# Altium schematic sheet 03_PL_ETH.SchDoc
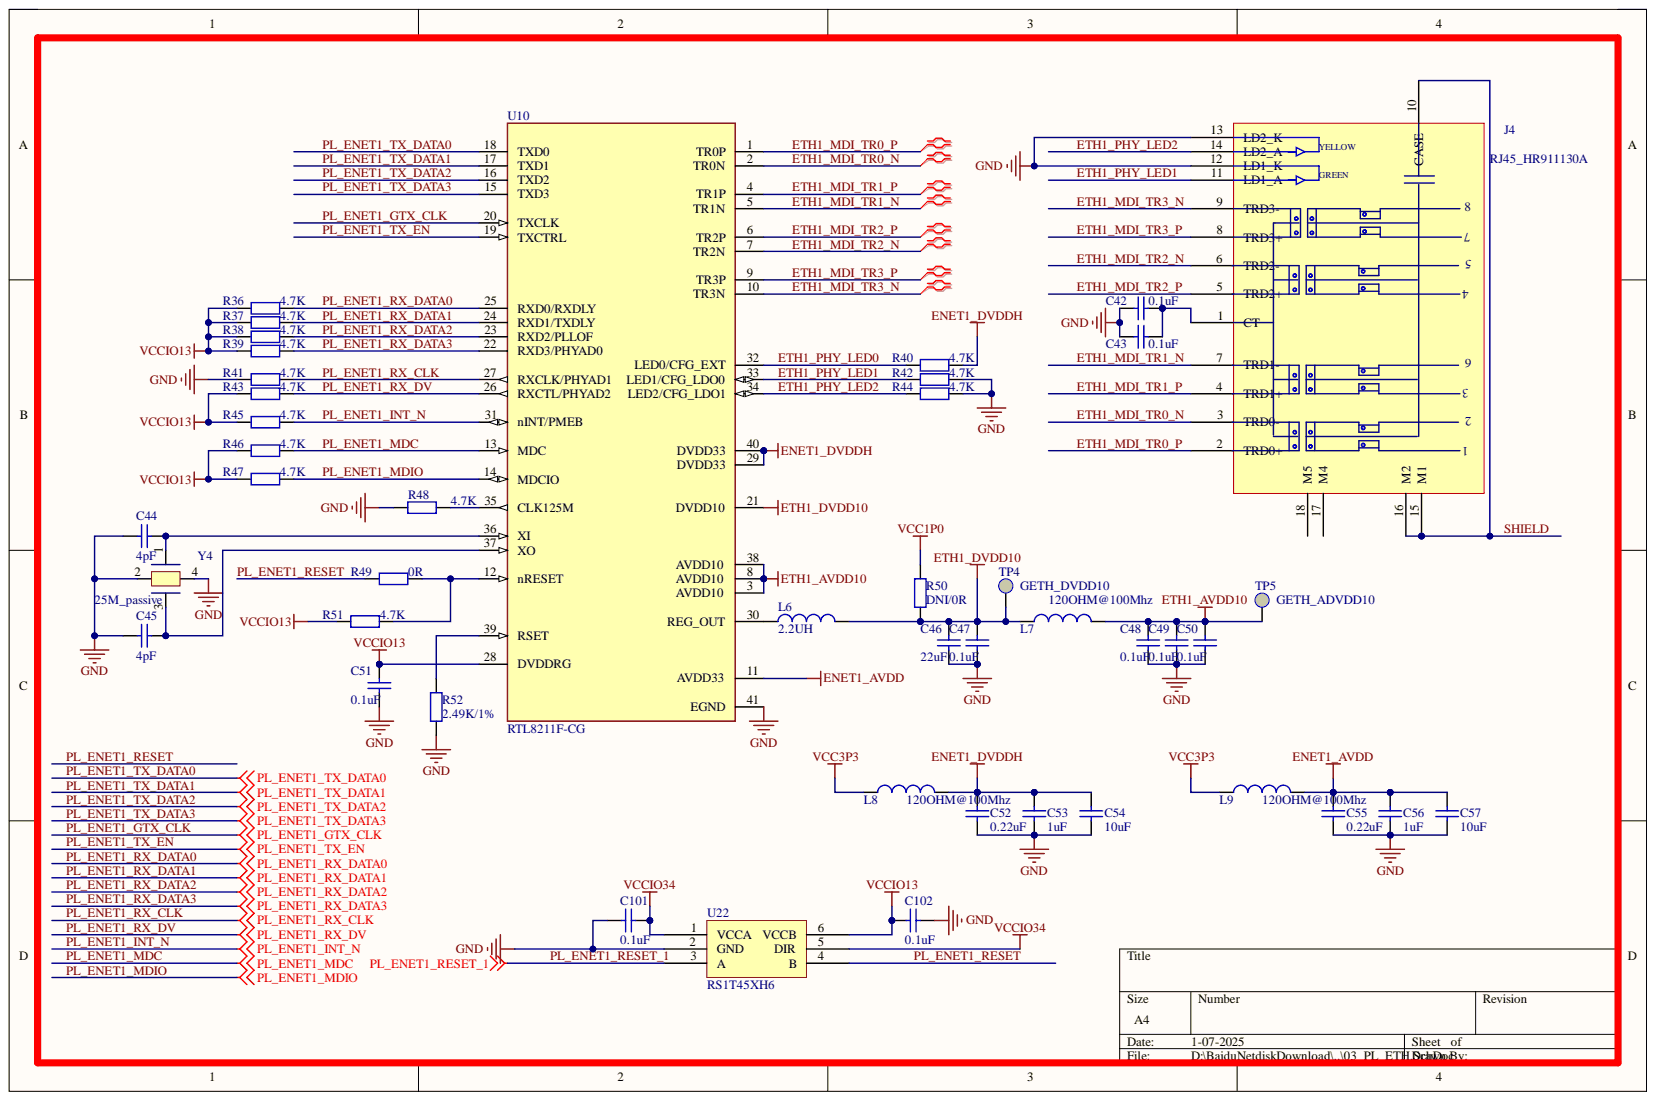
[diagram p0: 03_PL_ETH.SchDoc]

## Parts
C42  0.1uF  [C0603]
C43  0.1uF  [C0603]
C44  4pF  [C0603]
C45  4pF  [C0603]
C46  22uF  [C0805]
C47  0.1uF  [C0603]
C48  0.1uF  [C0603]
C49  0.1uF  [C0603]
C50  0.1uF  [C0603]
C51  0.1uF  [C0603]
C52  0.22uF  [C0603]
C53  1uF  [C0603]
C54  10uF  [C0805]
C55  0.22uF  [C0603]
C56  1uF  [C0603]
C57  10uF  [C0805]
C101  0.1uF  [C0603]
C102  0.1uF  [C0603]
J4  RJ45_HR911130A  [RJ45_HR911130C]
L6  2.2UH  [L0603]
L7  120OHM@100Mhz  [L0603]
L8  120OHM@100Mhz  [L0603]
L9  120OHM@100Mhz  [L0603]
R36  4.7K  [0603R]
R37  4.7K  [0603R]
R38  4.7K  [0603R]
R39  4.7K  [0603R]
R40  4.7K  [0603R]
R41  4.7K  [0603R]
R42  4.7K  [0603R]
R43  4.7K  [0603R]
R44  4.7K  [0603R]
R45  4.7K  [0603R]
R46  4.7K  [0603R]
R47  4.7K  [0603R]
R48  4.7K  [0603R]
R49  0R  [R0603]
R50  DNI/0R  [0603R]
R51  4.7K  [0603R]
R52  2.49K/1%  [0603R]
TP4  GETH_DVDD10  [tp32]
TP5  GETH_ADVDD10  [tp32]
U10  RTL8211F-CG  [qfn-40_l5_0-w5_0-p0_4-bl-ep]
U22  RS1T45XH6  [SOT23-6]
Y4  25M_passive  [X4-3225]

## Nets
ENET1_AVDD: C55.2 C56.2 C57.2 L9.2 U10.11(AVDD33)
ENET1_DVDDH: C52.2 C53.2 C54.2 L8.2 R40.2 U10.29(DVDD33) U10.40(DVDD33)
ETH1_AVDD10: C48.1 C49.1 C50.1 L7.2 TP5.1 U10.3(AVDD10) U10.8(AVDD10) U10.38(AVDD10)
ETH1_DVDD10: C46.1 C47.1 L6.2 L7.1 R50.2 TP4.1 U10.21(DVDD10)
GND: C42.1 C43.1 C44.1 C45.1 C46.2 C47.2 C48.2 C49.2 C50.2 C51.2 C52.1 C53.1 C54.1 C55.1 C56.1 C57.1 C101.1 C102.2 J4.12(LD1_K) J4.13(LD2_K) R41.1 R42.2 R44.2 R48.2 R52.1 U10.41(EGND) U22.2(GND) Y4.2 Y4.4
VCC1P0: R50.1
VCC3P3: L8.1 L9.1
VCCIO13: C51.1 C102.1 R36.1 R37.1 R38.1 R39.1 R43.1 R45.1 R46.1 R47.1 R51.1 U10.28(DVDDRG) U22.6(VCCB)
VCCIO34: C101.2 U22.1(VCCA) U22.5(DIR)
ETH1_MDI_TR0_N: J4.3(TRD0-) U10.2(TR0N)
ETH1_MDI_TR0_P: J4.2(TRD0+) U10.1(TR0P)
ETH1_MDI_TR1_N: J4.7(TRD1-) U10.5(TR1N)
ETH1_MDI_TR1_P: J4.4(TRD1+) U10.4(TR1P)
ETH1_MDI_TR2_N: J4.6(TRD2-) U10.7(TR2N)
ETH1_MDI_TR2_P: J4.5(TRD2+) U10.6(TR2P)
ETH1_MDI_TR3_N: J4.9(TRD3-) U10.10(TR3N)
ETH1_MDI_TR3_P: J4.8(TRD3+) U10.9(TR3P)
ETH1_PHY_LED0: R40.1 U10.32(LED0/CFG_EXT)
ETH1_PHY_LED1: J4.11(LD1_A) R42.1 U10.33(LED1/CFG_LDO0)
ETH1_PHY_LED2: J4.14(LD2_A) R44.1 U10.34(LED2/CFG_LDO1)
PL_ENET1_GTX_CLK: U10.20(TXCLK)
PL_ENET1_INT_N: R45.2 U10.31(nINT/PMEB)
PL_ENET1_MDC: R46.2 U10.13(MDC)
PL_ENET1_MDIO: R47.2 U10.14(MDCIO)
PL_ENET1_RESET: R49.1 U22.4(B)
PL_ENET1_RESET_1: U22.3(A)
PL_ENET1_RX_CLK: R41.2 U10.27(RXCLK/PHYAD1)
PL_ENET1_RX_DATA0: R36.2 U10.25(RXD0/RXDLY)
PL_ENET1_RX_DATA1: R37.2 U10.24(RXD1/TXDLY)
PL_ENET1_RX_DATA2: R38.2 U10.23(RXD2/PLLOF)
PL_ENET1_RX_DATA3: R39.2 U10.22(RXD3/PHYAD0)
PL_ENET1_RX_DV: R43.2 U10.26(RXCTL/PHYAD2)
PL_ENET1_TX_DATA0: U10.18(TXD0)
PL_ENET1_TX_DATA1: U10.17(TXD1)
PL_ENET1_TX_DATA2: U10.16(TXD2)
PL_ENET1_TX_DATA3: U10.15(TXD3)
PL_ENET1_TX_EN: U10.19(TXCTRL)
SHIELD: J4.10(CASE) J4.15(M1) J4.16(M2)
NetC42_2: C42.2 C43.2 J4.1(CT)
NetC44_2: C44.2 U10.36(XI) Y4.1
NetC45_2: C45.2 U10.37(XO) Y4.3
NetL6_1: L6.1 U10.30(REG_OUT)
NetR48_1: R48.1 U10.35(CLK125M)
NetR49_2: R49.2 R51.2 U10.12(nRESET)
NetR52_2: R52.2 U10.39(RSET)

Not connected: J4 17(M4) 18(M5)

## Library data of the parts
C42-C57 C101 C102 = Cap: Capacitor; Component Kind: Standard; LatestRevisionDate: 17-Jul-2002; LatestRevisionNote: Re-released for DXP Platform.; PackageReference: RAD-0.3; Published: 8-Jun-2000; Signal Integrity: Cap; Simulation: CAP; Publisher: Altium Limited
  C42 C43 C47-C51 C101 C102: Value: 0.1uF
  C44 C45: Library Name: Miscellaneous Devices.IntLib; PackageDescription: Radial Cap, Thru-Hole; 2 Leads; 0.3 in Pin Spacing; Value: 4pF
  C46: Value: 22uF
  C52 C55: Library Name: Miscellaneous Devices.IntLib; PackageDescription: Radial Cap, Thru-Hole; 2 Leads; 0.3 in Pin Spacing; Value: 0.22uF
  C53 C56: Library Name: Miscellaneous Devices.IntLib; PackageDescription: Radial Cap, Thru-Hole; 2 Leads; 0.3 in Pin Spacing; Value: 1uF
  C54 C57: Library Name: Miscellaneous Devices.IntLib; PackageDescription: Radial Cap, Thru-Hole; 2 Leads; 0.3 in Pin Spacing; Value: 10uF
  C42 C43 C46-C51 C101 C102: Library Name: 元件库封装.pcblib
J4 = GE_RJ45_2: Component Kind: Standard; Library Name: Schlib1.SchLib; Value: RJ45_HR911130A
L6 = Inductor: Code_IEC: 1005; Code_JEITA: 0402; Component Kind: Standard; Description: Inductor; LatestRevisionDate: 29-May-2009; LatestRevisionNote: IPC-7351 Footprint Added.; Library Name: Miscellaneous Devices.IntLib; PackageDescription: Chip Inductor; PackageReference: 0402-A; Published: 8-Jun-2000; Value: 2.2UH; Publisher: Altium Limited
L7-L9 = Inductor: Code_IEC: 1005; Value: 100ohm/1.2A; Code_JEITA: 0402; Component Kind: Standard; Description: Inductor; LatestRevisionDate: 29-May-2009; LatestRevisionNote: IPC-7351 Footprint Added.; Library Name: Miscellaneous Devices.IntLib; PackageDescription: Chip Inductor; PackageReference: 0402-A; Published: 8-Jun-2000; Publisher: Altium Limited
R36-R52 = Res2: Resistor; Component Kind: Standard; LatestRevisionDate: 17-Jul-2002; LatestRevisionNote: Re-released for DXP Platform.; PackageReference: AXIAL-0.4; Published: 8-Jun-2000; Library Name: 元件封装库.pcblib; Publisher: Altium Limited
  R36-R48 R51: Value: 4.7K
  R49: Value: 0R
  R50: Value: DNI/0R
  R52: Value: 2.49K/1%
TP4 TP5 = TestPoint: Component Kind: Standard; Library Name: USB302.Lib
U10 = RTL8211FDI: Component Kind: Standard; Library Name: gjws.SCHLIB
Y4 = OSC: Component Kind: Standard; Library Name: SCHLIB.SchLib
U22 = RS1T45XH6: Component Kind: Standard; Description: RS1T45XH6; Library Name: 7015.SchLib
